# Altium schematic sheet Input_Section.SchDoc
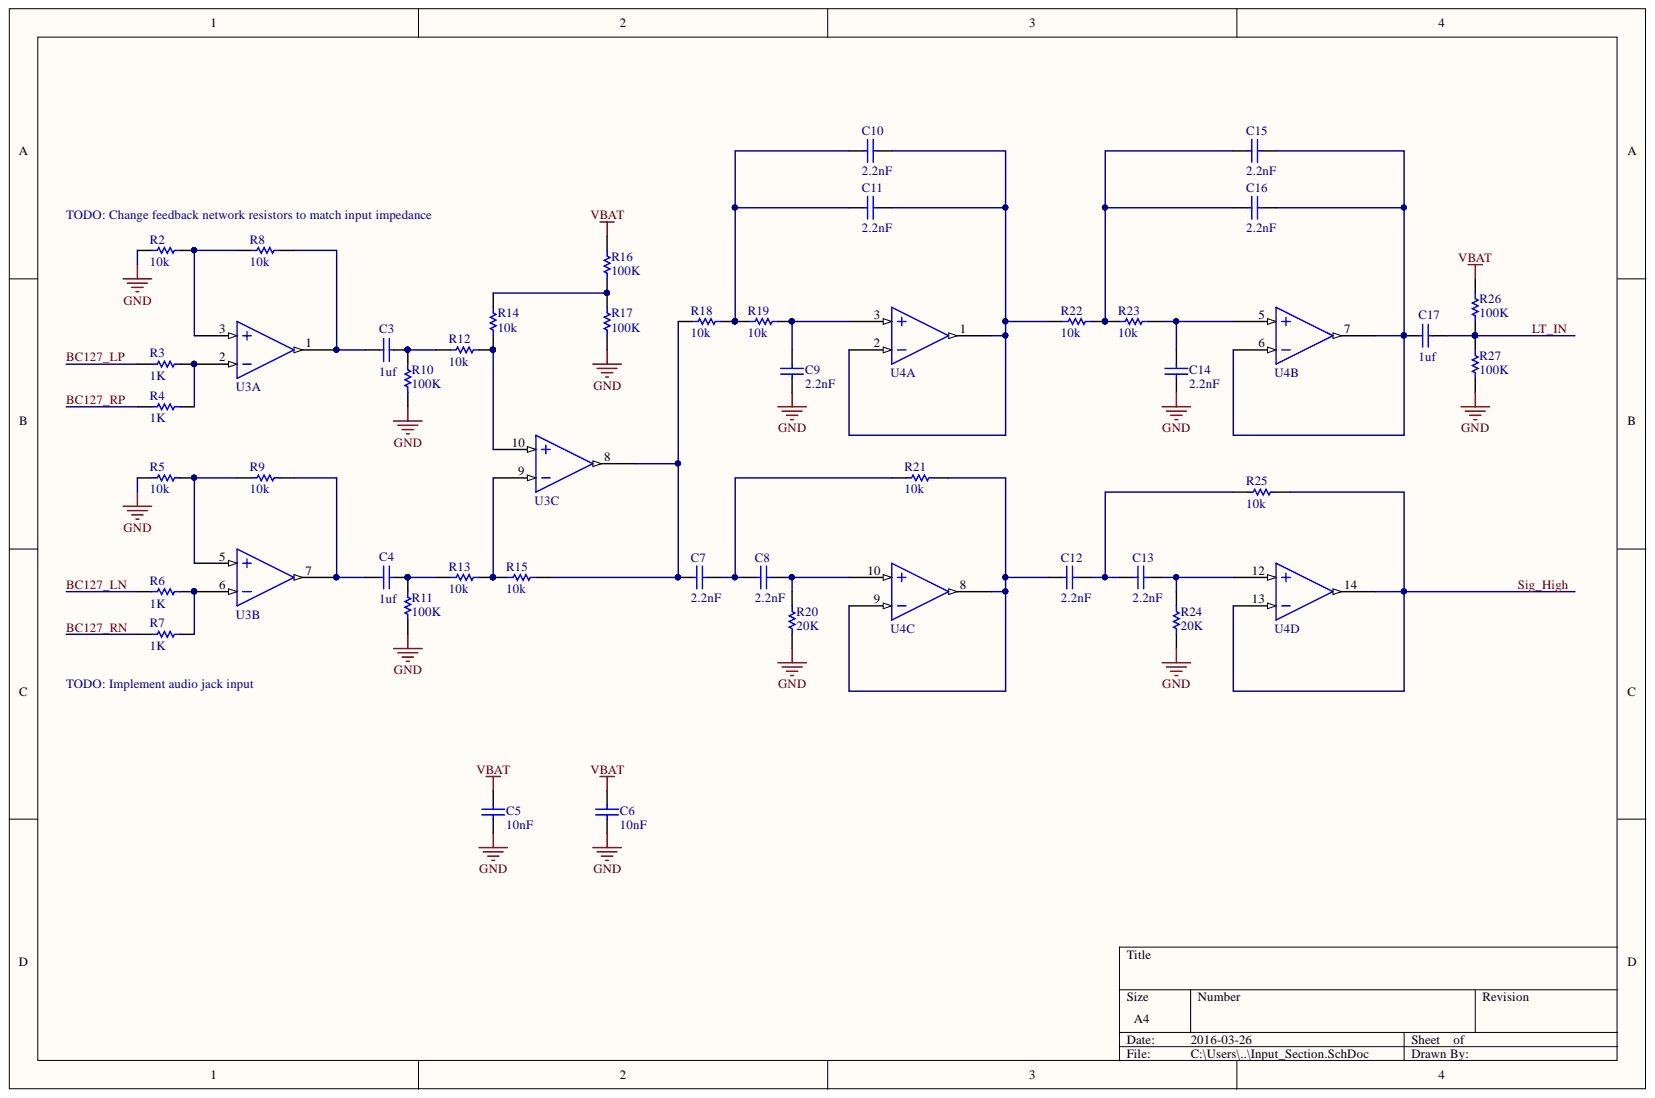
[diagram p0: Input_Section.SchDoc]

## Parts
C3  1uf
C4  1uf
C5  10nF  [CAPC1608X09N]
C6  10nF  [CAPC1608X09N]
C7  2.2nF  [CAPC1608X09N]
C8  2.2nF  [CAPC1608X09N]
C9  2.2nF  [CAPC1608X09N]
C10  2.2nF  [CAPC1608X09N]
C11  2.2nF  [CAPC1608X09N]
C12  2.2nF  [CAPC1608X09N]
C13  2.2nF  [CAPC1608X09N]
C14  2.2nF  [CAPC1608X09N]
C15  2.2nF  [CAPC1608X09N]
C16  2.2nF  [CAPC1608X09N]
C17  1uf
R2  10k  [0603]
R3  1K  [0603]
R4  1K  [0603]
R5  10k  [0603]
R6  1K  [0603]
R7  1K  [0603]
R8  10k  [0603]
R9  10k  [0603]
R10  100K  [0603]
R11  100K  [0603]
R12  10k  [0603]
R13  10k  [0603]
R14  10k  [0603]
R15  10k  [0603]
R16  100K  [0603]
R17  100K  [0603]
R18  10k  [0603]
R19  10k  [0603]
R20  20K  [0603]
R21  10k  [0603]
R22  10k  [0603]
R23  10k  [0603]
R24  20K  [0603]
R25  10k  [0603]
R26  100K  [0603]
R27  100K  [0603]
U3  OPA4134UA  [SOIC127P600X175-14N]  (value not drawn)  (parts A,B,C)
U4  OPA4134UA  [SOIC127P600X175-14N]  (value not drawn)  (parts A,B,C,D)

## Nets
GND: C5.1 C6.1 C9.1 C14.1 R2.1 R5.1 R10.1 R11.1 R17.1 R20.1 R24.2 R27.1 U3.11 U4.11
VBAT: C5.2 C6.2 R16.2 R26.2 U3.4 U4.4
BC127_LN: R6.1
BC127_LP: R3.1
BC127_RN: R7.1
BC127_RP: R4.1
LT_IN: C17.2 R26.1 R27.2
SIG_HIGH: R25.2 U4.13 U4.14
NetC3_1: C3.1 R8.2 U3.1
NetC3_2: C3.2 R10.2 R12.1
NetC4_1: C4.1 R9.2 U3.7
NetC4_2: C4.2 R11.2 R13.1
NetC7_1: C7.1 R15.1 R18.1 U3.8
NetC7_2: C7.2 C8.1 R21.1
NetC8_2: C8.2 R20.2 U4.10
NetC9_2: C9.2 R19.2 U4.3
NetC10_1: C10.1 C11.1 R18.2 R19.1
NetC10_2: C10.2 C11.2 R22.1 U4.1 U4.2
NetC12_1: C12.1 R21.2 U4.8 U4.9
NetC12_2: C12.2 C13.1 R25.1
NetC13_2: C13.2 R24.1 U4.12
NetC14_2: C14.2 R23.2 U4.5
NetC15_1: C15.1 C16.1 R22.2 R23.1
NetC15_2: C15.2 C16.2 C17.1 U4.6 U4.7
NetR2_2: R2.2 R8.1 U3.3
NetR3_2: R3.2 R4.2 U3.2
NetR5_2: R5.2 R9.1 U3.5
NetR6_2: R6.2 R7.2 U3.6
NetR12_2: R12.2 R14.1 U3.10
NetR13_2: R13.2 R15.2 U3.9
NetR14_2: R14.2 R16.1 R17.2

## Library data of the parts
C3 C4 C17 = CAP Radial 5mm: Manufacturer: EPCOS (TDK); Manufacturer P/N: B32529C105J; Voltage: 63V; Component Kind: Standard; Digikey Part Number: 495-1119-ND; Value: 1uf; Library Name: Speaker Library.SchLib
C5 C6 = Capacitor 10nF 0603: Capacitor 2.2nF 0805; Component Kind: Standard; Digikey Part Number: 311-1085-1-ND; Value: 10nF; Library Name: Speaker Library.SchLib
C7-C16 = CAP 2.2nF 0603: Capacitor 2.2nF 0603; Component Kind: Standard; Digikey Part Number: PCF1300CT-ND; Value: 2.2nF; Library Name: Speaker Library.SchLib
R2 R5 R8 R9 R12-R15 R18 R19 R21-R23 R25 = RES 10K 0603: Manufacturer: Yageo; Manufacturer Part Number: RC0603FR-0710KL; Power: 0.1W; Tolerance: 1%; Component Kind: Standard; Digikey Part Number: 311-10.0KHRCT-ND; Temperature: 155dC; Type: RES; Value: 10k; Library Name: Speaker Library.SchLib
R3 R4 R6 R7 = RES 1K 0603: Manufacturer: Panasonic; Manufacturer Part Number: ERJ-PA3F1001V; Power: 0.25W; Tolerance: 1%; Component Kind: Standard; Digikey Part Number: P1.0KBYCT-ND; Temperature: 155dC; Type: RES; Value: 1K; Library Name: Speaker Library.SchLib
R10 R11 R16 R17 R26 R27 = RES 100K 0603: Power: 0.1W; Tolerance: 1%; Component Kind: Standard; Value: 100K; Library Name: Speaker Library.SchLib
R20 R24 = RES 20K 0603: Power: 0.1W; Tolerance: 1%; Component Kind: Standard; Value: 20K; Library Name: Speaker Library.SchLib
U3 U4 = OPA4134UA: Quad OPAx134 Operational Amplifier; Component Kind: Standard; Library Name: Speaker Library.SchLib
